# Altium schematic sheet 核心板原理图1.SchDoc
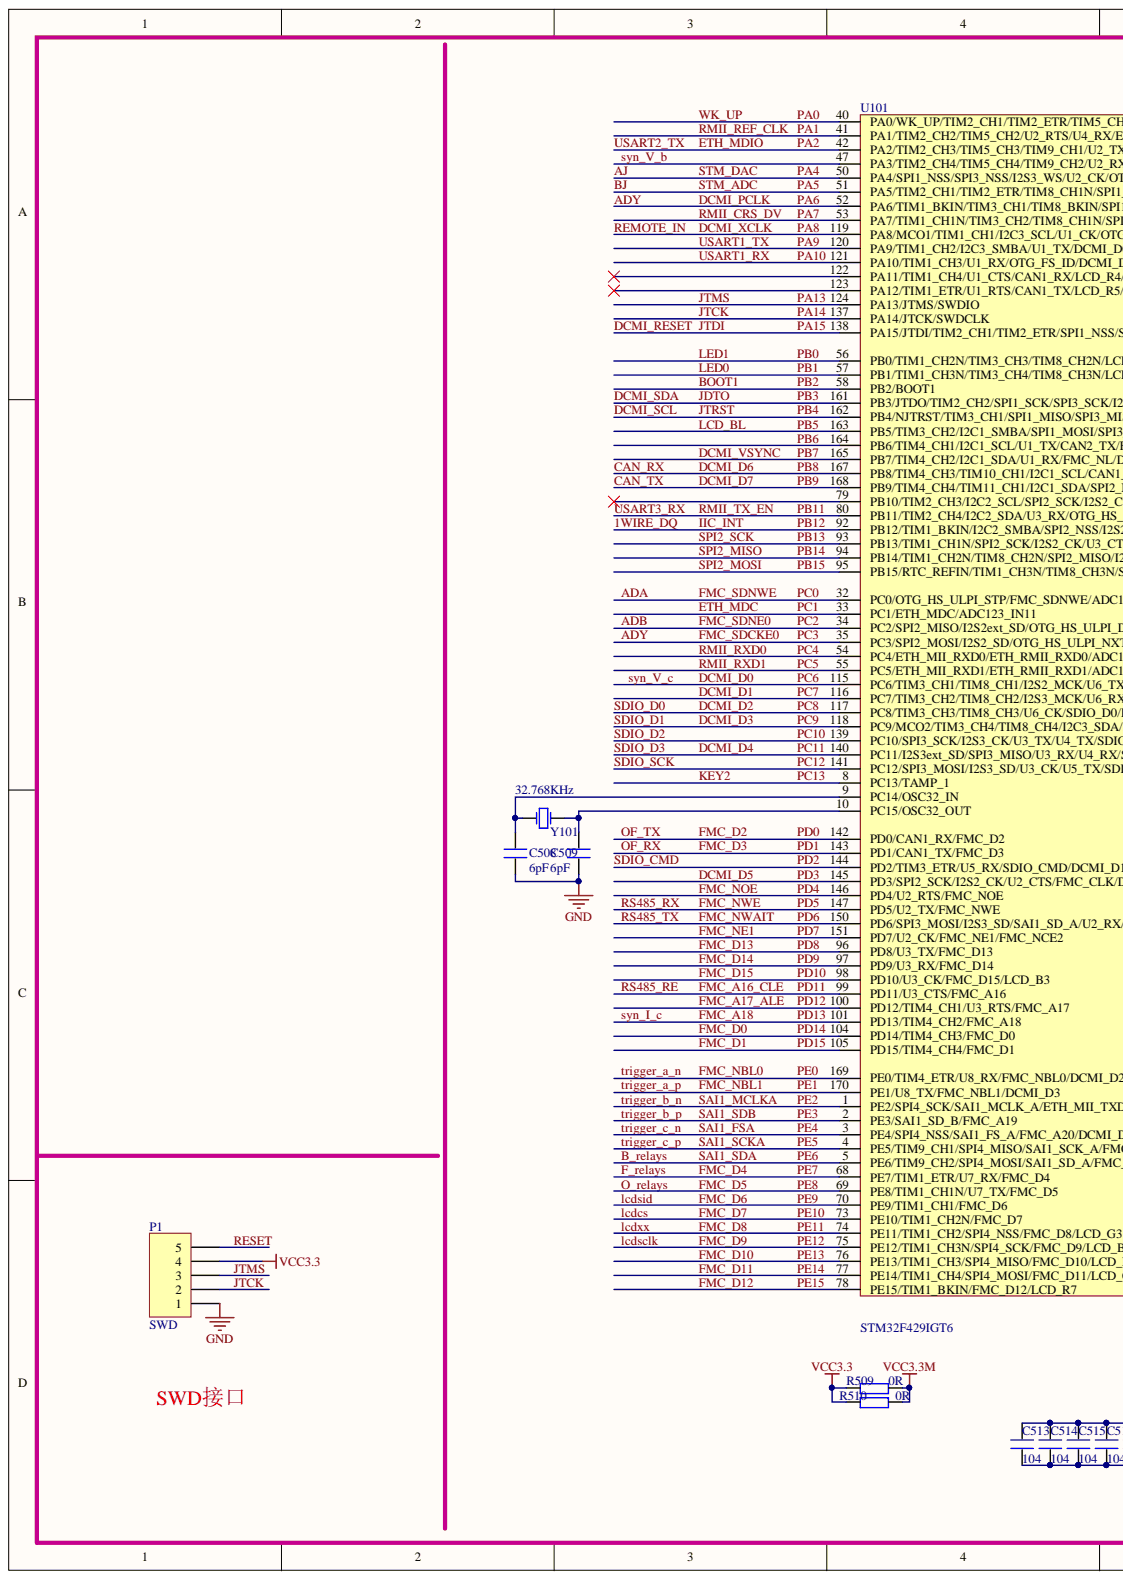
[diagram p0: 核心板原理图1.SchDoc, left]
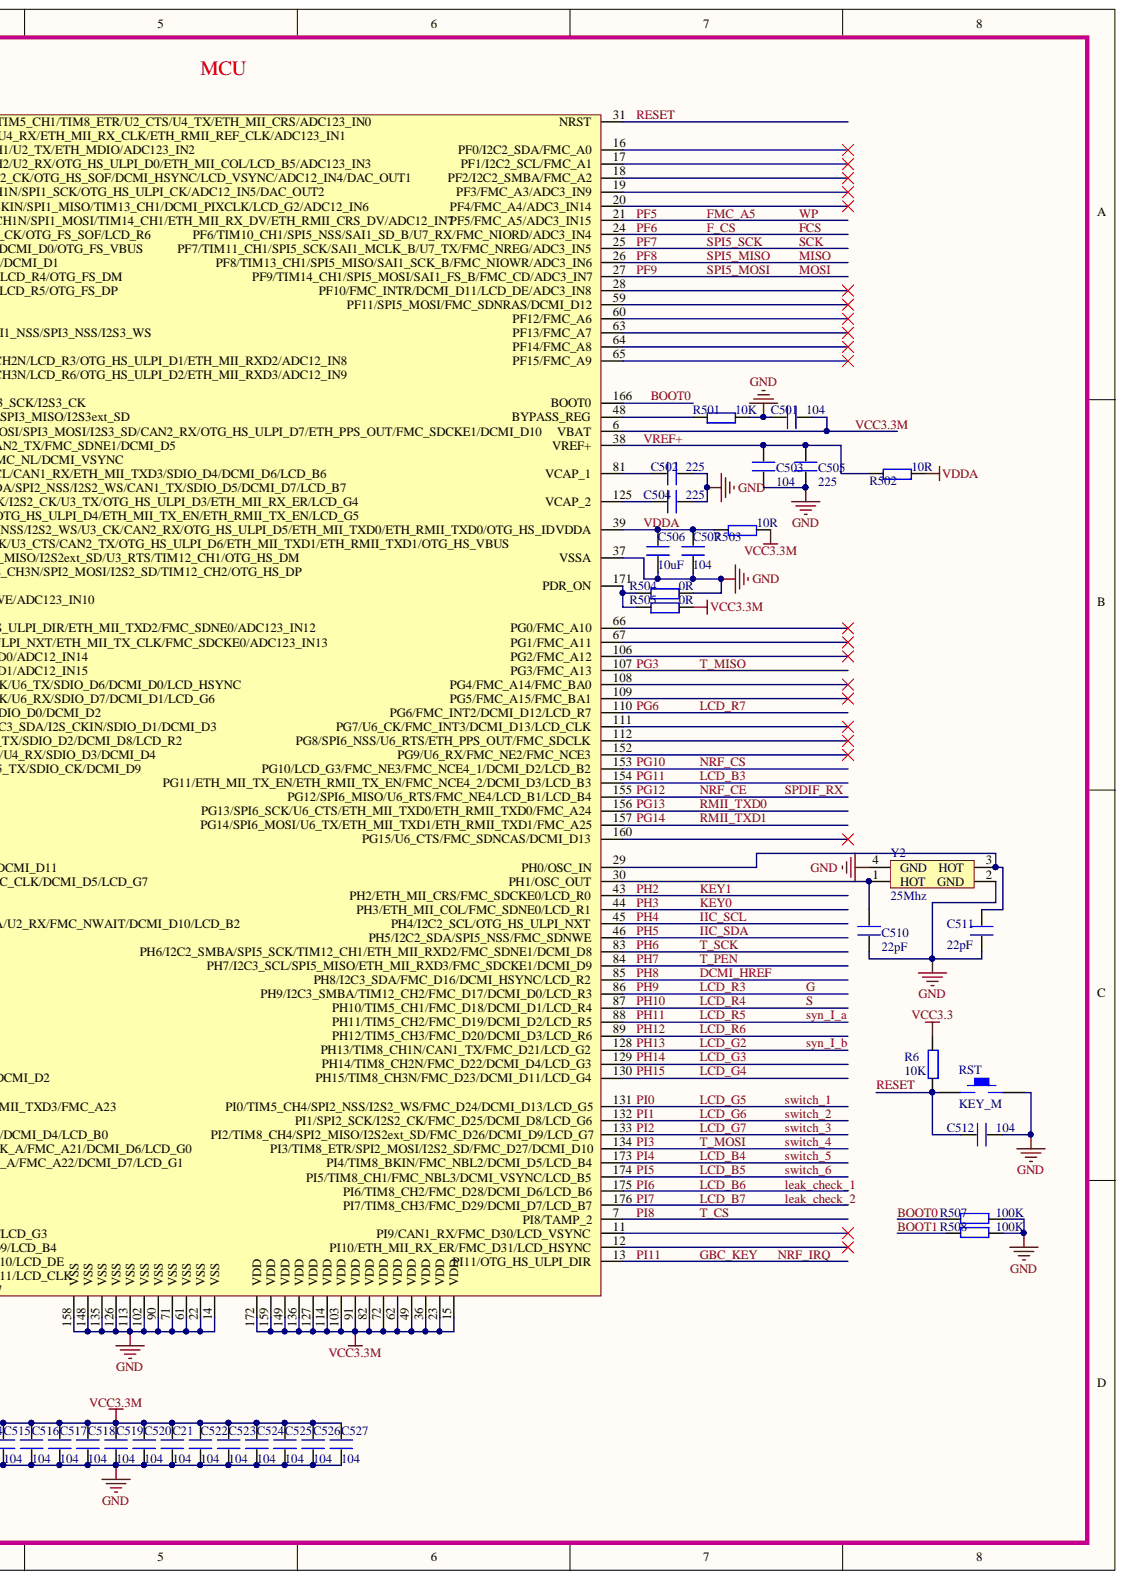
[diagram p1: 核心板原理图1.SchDoc, right]

## Parts
C21  104  [0402]
C501  104  [0402]
C502  225  [0402]
C503  104  [0402]
C504  225  [0402]
C505  225  [0402]
C506  10uF  [0603P]
C507  104  [0402]
C508  6pF  [0402]
C509  6pF  [0402]
C510  22pF  [0402]
C511  22pF  [0402]
C512  104  [0402]
C513  104  [0402]
C514  104  [0402]
C515  104  [0402]
C516  104  [0402]
C517  104  [0402]
C518  104  [0402]
C519  104  [0402]
C520  104  [0402]
C522  104  [0402]
C523  104  [0402]
C524  104  [0402]
C525  104  [0402]
C526  104  [0402]
C527  104  [0402]
P1  SWD  [HDR1X5]
R6  10K  [0402]
R501  10K  [0402]
R502  10R  [0402]
R503  10R  [0402]
R504  0R  [0402]
R505  0R  [0402]
R507  100K  [0402]
R508  100K  [0402]
R509  0R  [0402]
R510  0R  [0402]
RST  KEY_M  [KEY_SOP3625]
U101  STM32F429IGT6  [LQFP176]
Y2  25Mhz  [XTAL3225]
Y101  32.768KHz  [XTAL3215]

## Nets
GND: C21.1 C501.1 C502.2 C503.1 C504.1 C505.1 C506.1 C507.1 C508.1 C509.1 C510.1 C511.1 C512.2 C513.1 C514.1 C515.1 C516.1 C517.1 C518.1 C519.1 C520.1 C522.1 C523.1 C524.1 C525.1 C526.1 C527.1 P1.1 R501.2 R504.2 R507.1 R508.1 RST.2 U101.14(VSS) U101.22(VSS) U101.37(VSSA) U101.61(VSS) U101.71(VSS) U101.90(VSS) U101.102(VSS) U101.113(VSS) U101.126(VSS) U101.135(VSS) U101.148(VSS) U101.158(VSS) Y2.2(GND) Y2.4(GND)
VCC3.3: P1.4 R6.2 R509.1 R510.1
1WIRE_DQ: U101.92(PB12/TIM1_BKIN/I2C2_SMBA/SPI2_NSS/I2S2_WS/U3_CK/CAN2_RX/OTG_HS_ULPI_D5/ETH_MII_TXD0/ETH_RMII_TXD0/OTG_HS_ID)
ADA: U101.32(PC0/OTG_HS_ULPI_STP/FMC_SDNWE/ADC123_IN10)
ADB: U101.34(PC2/SPI2_MISO/I2S2ext_SD/OTG_HS_ULPI_DIR/ETH_MII_TXD2/FMC_SDNE0/ADC123_IN12)
ADY: U101.35(PC3/SPI2_MOSI/I2S2_SD/OTG_HS_ULPI_NXT/ETH_MII_TX_CLK/FMC_SDCKE0/ADC123_IN13) U101.52(PA6/TIM1_BKIN/TIM3_CH1/TIM8_BKIN/SPI1_MISO/TIM13_CH1/DCMI_PIXCLK/LCD_G2/ADC12_IN6)
AJ: U101.50(PA4/SPI1_NSS/SPI3_NSS/I2S3_WS/U2_CK/OTG_HS_SOF/DCMI_HSYNC/LCD_VSYNC/ADC12_IN4/DAC_OUT1)
B_relays: U101.5(PE6/TIM9_CH2/SPI4_MOSI/SAI1_SD_A/FMC_A22/DCMI_D7/LCD_G1)
BJ: U101.51(PA5/TIM2_CH1/TIM2_ETR/TIM8_CH1N/SPI1_SCK/OTG_HS_ULPI_CK/ADC12_IN5/DAC_OUT2)
BOOT0: R507.2 U101.166(BOOT0)
BOOT1: R508.2 U101.58(PB2/BOOT1)
CAN_RX: U101.167(PB8/TIM4_CH3/TIM10_CH1/I2C1_SCL/CAN1_RX/ETH_MII_TXD3/SDIO_D4/DCMI_D6/LCD_B6)
CAN_TX: U101.168(PB9/TIM4_CH4/TIM11_CH1/I2C1_SDA/SPI2_NSS/I2S2_WS/CAN1_TX/SDIO_D5/DCMI_D7/LCD_B7)
DCMI_D0: U101.115(PC6/TIM3_CH1/TIM8_CH1/I2S2_MCK/U6_TX/SDIO_D6/DCMI_D0/LCD_HSYNC)
DCMI_D1: U101.116(PC7/TIM3_CH2/TIM8_CH2/I2S3_MCK/U6_RX/SDIO_D7/DCMI_D1/LCD_G6)
DCMI_D2: U101.117(PC8/TIM3_CH3/TIM8_CH3/U6_CK/SDIO_D0/DCMI_D2)
DCMI_D3: U101.118(PC9/MCO2/TIM3_CH4/TIM8_CH4/I2C3_SDA/I2S_CKIN/SDIO_D1/DCMI_D3)
DCMI_D4: U101.140(PC11/I2S3ext_SD/SPI3_MISO/U3_RX/U4_RX/SDIO_D3/DCMI_D4)
DCMI_D5: U101.145(PD3/SPI2_SCK/I2S2_CK/U2_CTS/FMC_CLK/DCMI_D5/LCD_G7)
DCMI_HREF: U101.85(PH8/I2C3_SDA/FMC_D16/DCMI_HSYNC/LCD_R2)
DCMI_RESET: U101.138(PA15/JTDI/TIM2_CH1/TIM2_ETR/SPI1_NSS/SPI3_NSS/I2S3_WS)
DCMI_SCL: U101.162(PB4/NJTRST/TIM3_CH1/SPI1_MISO/SPI3_MISO/I2S3ext_SD)
DCMI_SDA: U101.161(PB3/JTDO/TIM2_CH2/SPI1_SCK/SPI3_SCK/I2S3_CK)
DCMI_VSYNC: U101.165(PB7/TIM4_CH2/I2C1_SDA/U1_RX/FMC_NL/DCMI_VSYNC)
DCMI_XCLK: U101.119(PA8/MCO1/TIM1_CH1/I2C3_SCL/U1_CK/OTG_FS_SOF/LCD_R6)
ETH_MDC: U101.33(PC1/ETH_MDC/ADC123_IN11)
ETH_MDIO: U101.42(PA2/TIM2_CH3/TIM5_CH3/TIM9_CH1/U2_TX/ETH_MDIO/ADC123_IN2)
F_CS: U101.24(PF6/TIM10_CH1/SPI5_NSS/SAI1_SD_B/U7_RX/FMC_NIORD/ADC3_IN4)
F_relays: U101.68(PE7/TIM1_ETR/U7_RX/FMC_D4)
FMC_A5: U101.21(PF5/FMC_A5/ADC3_IN15)
FMC_A16_CLE: U101.99(PD11/U3_CTS/FMC_A16)
FMC_A17_ALE: U101.100(PD12/TIM4_CH1/U3_RTS/FMC_A17)
FMC_A18: U101.101(PD13/TIM4_CH2/FMC_A18)
FMC_D0: U101.104(PD14/TIM4_CH3/FMC_D0)
FMC_D1: U101.105(PD15/TIM4_CH4/FMC_D1)
FMC_D2: U101.142(PD0/CAN1_RX/FMC_D2)
FMC_D3: U101.143(PD1/CAN1_TX/FMC_D3)
FMC_D5: U101.69(PE8/TIM1_CH1N/U7_TX/FMC_D5)
FMC_D6: U101.70(PE9/TIM1_CH1/FMC_D6)
FMC_D7: U101.73(PE10/TIM1_CH2N/FMC_D7)
FMC_D8: U101.74(PE11/TIM1_CH2/SPI4_NSS/FMC_D8/LCD_G3)
FMC_D9: U101.75(PE12/TIM1_CH3N/SPI4_SCK/FMC_D9/LCD_B4)
FMC_D10: U101.76(PE13/TIM1_CH3/SPI4_MISO/FMC_D10/LCD_DE)
FMC_D11: U101.77(PE14/TIM1_CH4/SPI4_MOSI/FMC_D11/LCD_CLK)
FMC_D12: U101.78(PE15/TIM1_BKIN/FMC_D12/LCD_R7)
FMC_D13: U101.96(PD8/U3_TX/FMC_D13)
FMC_D14: U101.97(PD9/U3_RX/FMC_D14)
FMC_D15: U101.98(PD10/U3_CK/FMC_D15/LCD_B3)
FMC_NBL0: U101.169(PE0/TIM4_ETR/U8_RX/FMC_NBL0/DCMI_D2)
FMC_NBL1: U101.170(PE1/U8_TX/FMC_NBL1/DCMI_D3)
FMC_NE1: U101.151(PD7/U2_CK/FMC_NE1/FMC_NCE2)
FMC_NOE: U101.146(PD4/U2_RTS/FMC_NOE)
FMC_NWAIT: U101.150(PD6/SPI3_MOSI/I2S3_SD/SAI1_SD_A/U2_RX/FMC_NWAIT/DCMI_D10/LCD_B2)
FMC_NWE: U101.147(PD5/U2_TX/FMC_NWE)
G: U101.86(PH9/I2C3_SMBA/TIM12_CH2/FMC_D17/DCMI_D0/LCD_R3)
GBC_KEY: U101.13(PI11/OTG_HS_ULPI_DIR)
IIC_SCL: U101.45(PH4/I2C2_SCL/OTG_HS_ULPI_NXT)
IIC_SDA: U101.46(PH5/I2C2_SDA/SPI5_NSS/FMC_SDNWE)
JTCK: P1.2 U101.137(PA14/JTCK/SWDCLK)
JTMS: P1.3 U101.124(PA13/JTMS/SWDIO)
KEY0: U101.44(PH3/ETH_MII_COL/FMC_SDNE0/LCD_R1)
KEY1: U101.43(PH2/ETH_MII_CRS/FMC_SDCKE0/LCD_R0)
KEY2: U101.8(PC13/TAMP_1)
LCD_B3: U101.154(PG11/ETH_MII_TX_EN/ETH_RMII_TX_EN/FMC_NCE4_2/DCMI_D3/LCD_B3)
LCD_B4: U101.173(PI4/TIM8_BKIN/FMC_NBL2/DCMI_D5/LCD_B4)
LCD_B5: U101.174(PI5/TIM8_CH1/FMC_NBL3/DCMI_VSYNC/LCD_B5)
LCD_B6: U101.175(PI6/TIM8_CH2/FMC_D28/DCMI_D6/LCD_B6)
LCD_B7: U101.176(PI7/TIM8_CH3/FMC_D29/DCMI_D7/LCD_B7)
LCD_BL: U101.163(PB5/TIM3_CH2/I2C1_SMBA/SPI1_MOSI/SPI3_MOSI/I2S3_SD/CAN2_RX/OTG_HS_ULPI_D7/ETH_PPS_OUT/FMC_SDCKE1/DCMI_D10)
LCD_G2: U101.128(PH13/TIM8_CH1N/CAN1_TX/FMC_D21/LCD_G2)
LCD_G3: U101.129(PH14/TIM8_CH2N/FMC_D22/DCMI_D4/LCD_G3)
LCD_G4: U101.130(PH15/TIM8_CH3N/FMC_D23/DCMI_D11/LCD_G4)
LCD_G5: U101.131(PI0/TIM5_CH4/SPI2_NSS/I2S2_WS/FMC_D24/DCMI_D13/LCD_G5)
LCD_G6: U101.132(PI1/SPI2_SCK/I2S2_CK/FMC_D25/DCMI_D8/LCD_G6)
LCD_G7: U101.133(PI2/TIM8_CH4/SPI2_MISO/I2S2ext_SD/FMC_D26/DCMI_D9/LCD_G7)
LCD_R4: U101.87(PH10/TIM5_CH1/FMC_D18/DCMI_D1/LCD_R4)
LCD_R5: U101.88(PH11/TIM5_CH2/FMC_D19/DCMI_D2/LCD_R5)
LCD_R6: U101.89(PH12/TIM5_CH3/FMC_D20/DCMI_D3/LCD_R6)
LCD_R7: U101.110(PG6/FMC_INT2/DCMI_D12/LCD_R7)
LED0: U101.57(PB1/TIM1_CH3N/TIM3_CH4/TIM8_CH3N/LCD_R6/OTG_HS_ULPI_D2/ETH_MII_RXD3/ADC12_IN9)
LED1: U101.56(PB0/TIM1_CH2N/TIM3_CH3/TIM8_CH2N/LCD_R3/OTG_HS_ULPI_D1/ETH_MII_RXD2/ADC12_IN8)
MISO: U101.26(PF8/TIM13_CH1/SPI5_MISO/SAI1_SCK_B/FMC_NIOWR/ADC3_IN6)
MOSI: U101.27(PF9/TIM14_CH1/SPI5_MOSI/SAI1_FS_B/FMC_CD/ADC3_IN7)
NRF_CE: U101.155(PG12/SPI6_MISO/U6_RTS/FMC_NE4/LCD_B1/LCD_B4)
NRF_CS: U101.153(PG10/LCD_G3/FMC_NE3/FMC_NCE4_1/DCMI_D2/LCD_B2)
PA0: U101.40(PA0/WK_UP/TIM2_CH1/TIM2_ETR/TIM5_CH1/TIM8_ETR/U2_CTS/U4_TX/ETH_MII_CRS/ADC123_IN0)
PA1: U101.41(PA1/TIM2_CH2/TIM5_CH2/U2_RTS/U4_RX/ETH_MII_RX_CLK/ETH_RMII_REF_CLK/ADC123_IN1)
PA7: U101.53(PA7/TIM1_CH1N/TIM3_CH2/TIM8_CH1N/SPI1_MOSI/TIM14_CH1/ETH_MII_RX_DV/ETH_RMII_CRS_DV/ADC12_IN7)
PA9: U101.120(PA9/TIM1_CH2/I2C3_SMBA/U1_TX/DCMI_D0/OTG_FS_VBUS)
PA10: U101.121(PA10/TIM1_CH3/U1_RX/OTG_FS_ID/DCMI_D1)
PB6: U101.164(PB6/TIM4_CH1/I2C1_SCL/U1_TX/CAN2_TX/FMC_SDNE1/DCMI_D5)
PB11: U101.80(PB11/TIM2_CH4/I2C2_SDA/U3_RX/OTG_HS_ULPI_D4/ETH_MII_TX_EN/ETH_RMII_TX_EN/LCD_G5)
PB13: U101.93(PB13/TIM1_CH1N/SPI2_SCK/I2S2_CK/U3_CTS/CAN2_TX/OTG_HS_ULPI_D6/ETH_MII_TXD1/ETH_RMII_TXD1/OTG_HS_VBUS)
PB14: U101.94(PB14/TIM1_CH2N/TIM8_CH2N/SPI2_MISO/I2S2ext_SD/U3_RTS/TIM12_CH1/OTG_HS_DM)
PB15: U101.95(PB15/RTC_REFIN/TIM1_CH3N/TIM8_CH3N/SPI2_MOSI/I2S2_SD/TIM12_CH2/OTG_HS_DP)
PC4: U101.54(PC4/ETH_MII_RXD0/ETH_RMII_RXD0/ADC12_IN14)
PC5: U101.55(PC5/ETH_MII_RXD1/ETH_RMII_RXD1/ADC12_IN15)
PC10: U101.139(PC10/SPI3_SCK/I2S3_CK/U3_TX/U4_TX/SDIO_D2/DCMI_D8/LCD_R2)
PC12: U101.141(PC12/SPI3_MOSI/I2S3_SD/U3_CK/U5_TX/SDIO_CK/DCMI_D9)
PD2: U101.144(PD2/TIM3_ETR/U5_RX/SDIO_CMD/DCMI_D11)
PE2: U101.1(PE2/SPI4_SCK/SAI1_MCLK_A/ETH_MII_TXD3/FMC_A23)
PE3: U101.2(PE3/SAI1_SD_B/FMC_A19)
PE4: U101.3(PE4/SPI4_NSS/SAI1_FS_A/FMC_A20/DCMI_D4/LCD_B0)
PE5: U101.4(PE5/TIM9_CH1/SPI4_MISO/SAI1_SCK_A/FMC_A21/DCMI_D6/LCD_G0)
PF7: U101.25(PF7/TIM11_CH1/SPI5_SCK/SAI1_MCLK_B/U7_TX/FMC_NREG/ADC3_IN5)
PG3: U101.107(PG3/FMC_A13)
PG13: U101.156(PG13/SPI6_SCK/U6_CTS/ETH_MII_TXD0/ETH_RMII_TXD0/FMC_A24)
PG14: U101.157(PG14/SPI6_MOSI/U6_TX/ETH_MII_TXD1/ETH_RMII_TXD1/FMC_A25)
PH6: U101.83(PH6/I2C2_SMBA/SPI5_SCK/TIM12_CH1/ETH_MII_RXD2/FMC_SDNE1/DCMI_D8)
PH7: U101.84(PH7/I2C3_SCL/SPI5_MISO/ETH_MII_RXD3/FMC_SDCKE1/DCMI_D9)
PI3: U101.134(PI3/TIM8_ETR/SPI2_MOSI/I2S2_SD/FMC_D27/DCMI_D10)
PI8: U101.7(PI8/TAMP_2)
RESET: C512.1 P1.5 R6.1 RST.1 U101.31(NRST)
syn_V_b: U101.47(PA3/TIM2_CH4/TIM5_CH4/TIM9_CH2/U2_RX/OTG_HS_ULPI_D0/ETH_MII_COL/LCD_B5/ADC123_IN3)
VCC3.3M: C21.2 C501.2 C513.2 C514.2 C515.2 C516.2 C517.2 C518.2 C519.2 C520.2 C522.2 C523.2 C524.2 C525.2 C526.2 C527.2 R503.2 R505.2 R509.2 R510.2 U101.6(VBAT) U101.15(VDD) U101.23(VDD) U101.36(VDD) U101.49(VDD) U101.62(VDD) U101.72(VDD) U101.82(VDD) U101.91(VDD) U101.103(VDD) U101.114(VDD) U101.127(VDD) U101.136(VDD) U101.149(VDD) U101.159(VDD) U101.172(VDD)
VDDA: C506.2 C507.2 R502.2 R503.1 U101.39(VDDA)
VREF+: C503.2 C505.2 R502.1 U101.38(VREF+)
NetC502_1: C502.1 U101.81(VCAP_1)
NetC504_2: C504.2 U101.125(VCAP_2)
NetC508_2: C508.2 U101.9(PC14/OSC32_IN) Y101.1
NetC509_2: C509.2 U101.10(PC15/OSC32_OUT) Y101.2
NetC510_2: C510.2 U101.30(PH1/OSC_OUT) Y2.1(HOT)
NetC511_2: C511.2 U101.29(PH0/OSC_IN) Y2.3(HOT)
NetR501_1: R501.1 U101.48(BYPASS_REG)
NetR504_1: R504.1 R505.1 U101.171(PDR_ON)

Not connected: U101 11(PI9/CAN1_RX/FMC_D30/LCD_VSYNC) 12(PI10/ETH_MII_RX_ER/FMC_D31/LCD_HSYNC) 16(PF0/I2C2_SDA/FMC_A0) 17(PF1/I2C2_SCL/FMC_A1) 18(PF2/I2C2_SMBA/FMC_A2) 19(PF3/FMC_A3/ADC3_IN9) 20(PF4/FMC_A4/ADC3_IN14) 28(PF10/FMC_INTR/DCMI_D11/LCD_DE/ADC3_IN8) 59(PF11/SPI5_MOSI/FMC_SDNRAS/DCMI_D12) 60(PF12/FMC_A6) 63(PF13/FMC_A7) 64(PF14/FMC_A8) 65(PF15/FMC_A9) 66(PG0/FMC_A10) 67(PG1/FMC_A11) 79(PB10/TIM2_CH3/I2C2_SCL/SPI2_SCK/I2S2_CK/U3_TX/OTG_HS_ULPI_D3/ETH_MII_RX_ER/LCD_G4) 106(PG2/FMC_A12) 108(PG4/FMC_A14/FMC_BA0) 109(PG5/FMC_A15/FMC_BA1) 111(PG7/U6_CK/FMC_INT3/DCMI_D13/LCD_CLK) 112(PG8/SPI6_NSS/U6_RTS/ETH_PPS_OUT/FMC_SDCLK) 122(PA11/TIM1_CH4/U1_CTS/CAN1_RX/LCD_R4/OTG_FS_DM) 123(PA12/TIM1_ETR/U1_RTS/CAN1_TX/LCD_R5/OTG_FS_DP) 152(PG9/U6_RX/FMC_NE2/FMC_NCE3) 160(PG15/U6_CTS/FMC_SDNCAS/DCMI_D13)

## Library data of the parts
C21 C501-C520 C522-C527 = C: Component Kind: Standard; Library Name: STM32F429_CORE.SCHLIB
P1 = Header 5: Header, 5-Pin; Component Kind: Standard; LatestRevisionDate: 17-Jul-2002; LatestRevisionNote: Re-released for DXP Platform.; Library Name: STM32F429_CORE.SCHLIB; Signal Integrity: Connector; Publisher: Altium Limited
R6 R501-R505 R507-R510 = R: Component Kind: Standard; Library Name: STM32F429_CORE.SCHLIB
Y2 = XTAL_3225: 3225贴片无源晶振; Component Kind: Standard; Library Name: STM32F429_CORE.SCHLIB
Y101 = XTAL: 普通晶振; Component Kind: Standard; LatestRevisionDate: 17-Jul-2002; LatestRevisionNote: Re-released for DXP Platform.; Library Name: STM32F429_CORE.SCHLIB; Published: 8-Jun-2000; Publisher: Altium Limited
RST = KEY_M: Component Kind: Standard; Library Name: STM32F429_CORE.SCHLIB
U101 = STM32F429IGT6: Component Kind: Standard; Description: STM32F429IGT6; Library Name: STM32F429_CORE.SCHLIB
